# Altium schematic sheet Center Console - Buttons Interface.SchDoc("Center Console - Buttons Interface")
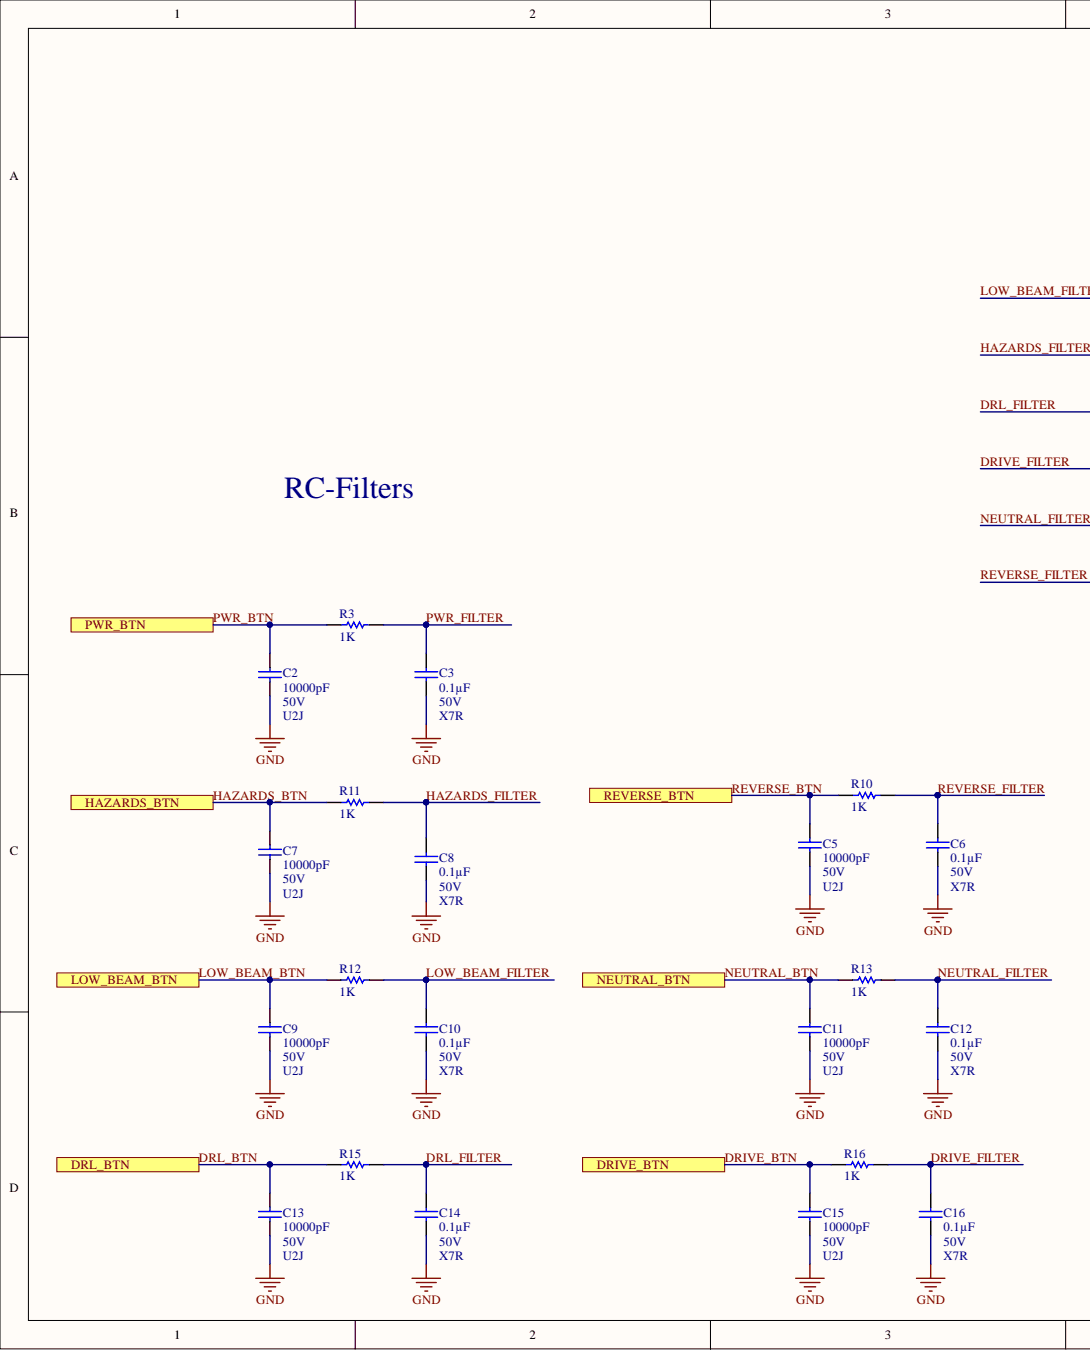
[diagram p0: Center Console - Buttons Interface.SchDoc, left]
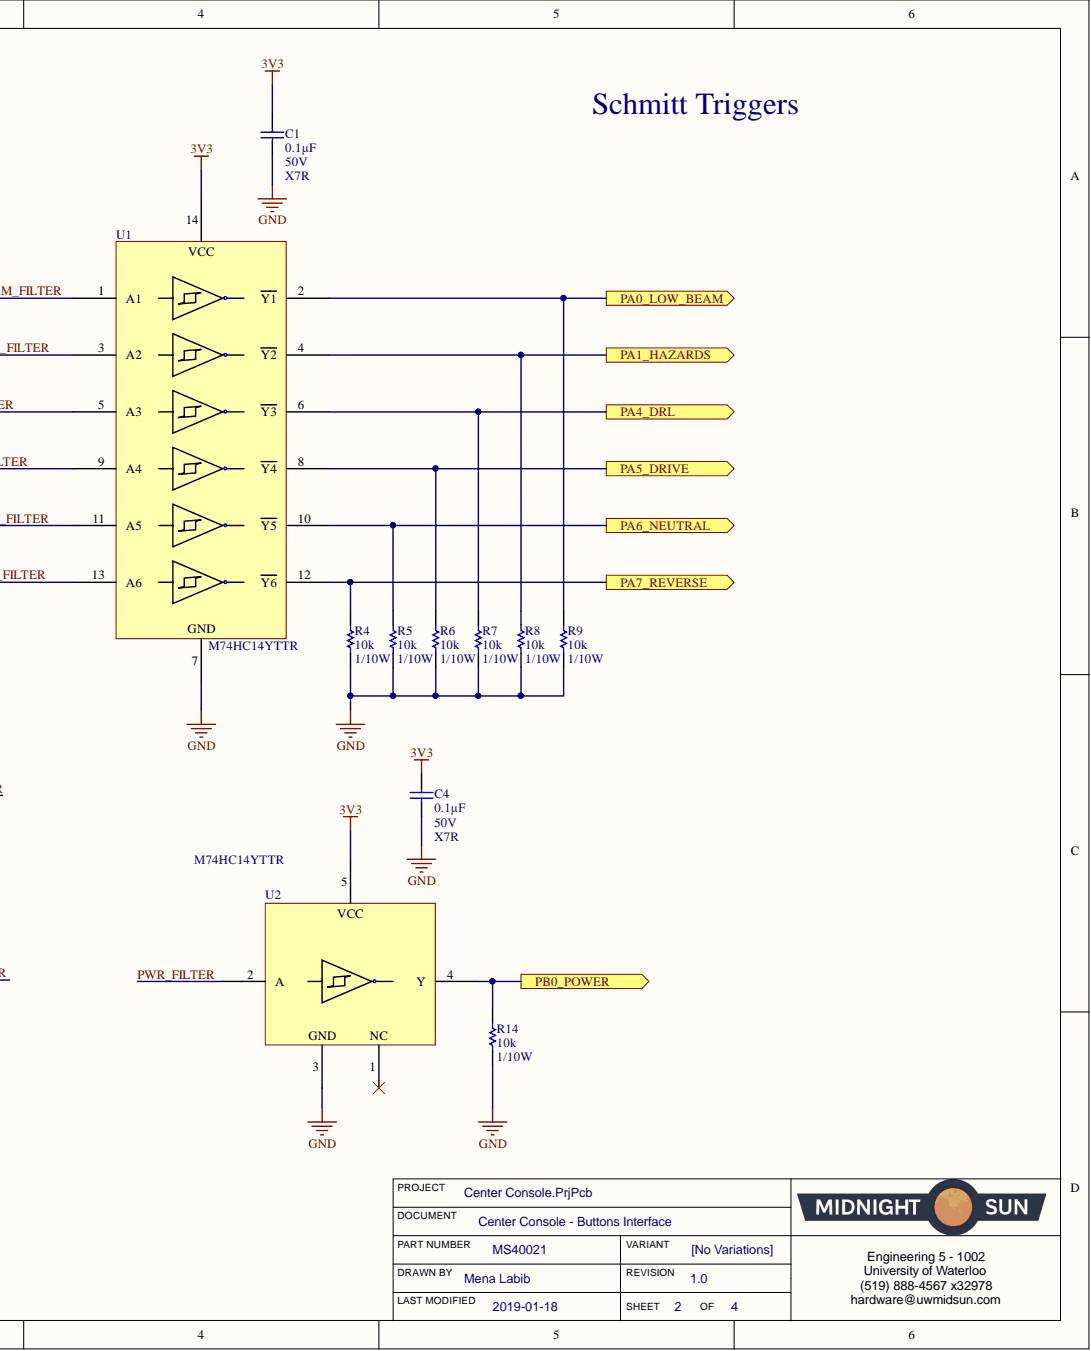
[diagram p1: Center Console - Buttons Interface.SchDoc, right]

## Parts
C1  Voltage: 50V  Temperature Coefficient: X7R  Capacitance: 0.1µF  [CAP, 0603]  (hidden comment: CAP CER 0.1UF 50V 10% X7R 0603)
C2  Voltage: 50V  Temperature Coefficient: U2J  Capacitance: 10000pF  [CAP, 0603]  (hidden comment: CAP CER 10nF 50V 5% X7R 0603)
C3  Voltage: 50V  Temperature Coefficient: X7R  Capacitance: 0.1µF  [CAP, 0603]  (hidden comment: CAP CER 0.1UF 50V 10% X7R 0603)
C4  Voltage: 50V  Temperature Coefficient: X7R  Capacitance: 0.1µF  [CAP, 0603]  (hidden comment: CAP CER 0.1UF 50V 10% X7R 0603)
C5  Voltage: 50V  Temperature Coefficient: U2J  Capacitance: 10000pF  [CAP, 0603]  (hidden comment: CAP CER 10nF 50V 5% X7R 0603)
C6  Voltage: 50V  Temperature Coefficient: X7R  Capacitance: 0.1µF  [CAP, 0603]  (hidden comment: CAP CER 0.1UF 50V 10% X7R 0603)
C7  Voltage: 50V  Temperature Coefficient: U2J  Capacitance: 10000pF  [CAP, 0603]  (hidden comment: CAP CER 10nF 50V 5% X7R 0603)
C8  Voltage: 50V  Temperature Coefficient: X7R  Capacitance: 0.1µF  [CAP, 0603]  (hidden comment: CAP CER 0.1UF 50V 10% X7R 0603)
C9  Voltage: 50V  Temperature Coefficient: U2J  Capacitance: 10000pF  [CAP, 0603]  (hidden comment: CAP CER 10nF 50V 5% X7R 0603)
C10  Voltage: 50V  Temperature Coefficient: X7R  Capacitance: 0.1µF  [CAP, 0603]  (hidden comment: CAP CER 0.1UF 50V 10% X7R 0603)
C11  Voltage: 50V  Temperature Coefficient: U2J  Capacitance: 10000pF  [CAP, 0603]  (hidden comment: CAP CER 10nF 50V 5% X7R 0603)
C12  Voltage: 50V  Temperature Coefficient: X7R  Capacitance: 0.1µF  [CAP, 0603]  (hidden comment: CAP CER 0.1UF 50V 10% X7R 0603)
C13  Voltage: 50V  Temperature Coefficient: U2J  Capacitance: 10000pF  [CAP, 0603]  (hidden comment: CAP CER 10nF 50V 5% X7R 0603)
C14  Voltage: 50V  Temperature Coefficient: X7R  Capacitance: 0.1µF  [CAP, 0603]  (hidden comment: CAP CER 0.1UF 50V 10% X7R 0603)
C15  Voltage: 50V  Temperature Coefficient: U2J  Capacitance: 10000pF  [CAP, 0603]  (hidden comment: CAP CER 10nF 50V 5% X7R 0603)
C16  Voltage: 50V  Temperature Coefficient: X7R  Capacitance: 0.1µF  [CAP, 0603]  (hidden comment: CAP CER 0.1UF 50V 10% X7R 0603)
R3  1K  [RES, 0603]
R4  Resistance: 10k  Power: 1/10W  [RES, 0603]  (hidden comment: RES 10K OHM 1% 1/10W 0603)
R5  Resistance: 10k  Power: 1/10W  [RES, 0603]  (hidden comment: RES 10K OHM 1% 1/10W 0603)
R6  Resistance: 10k  Power: 1/10W  [RES, 0603]  (hidden comment: RES 10K OHM 1% 1/10W 0603)
R7  Resistance: 10k  Power: 1/10W  [RES, 0603]  (hidden comment: RES 10K OHM 1% 1/10W 0603)
R8  Resistance: 10k  Power: 1/10W  [RES, 0603]  (hidden comment: RES 10K OHM 1% 1/10W 0603)
R9  Resistance: 10k  Power: 1/10W  [RES, 0603]  (hidden comment: RES 10K OHM 1% 1/10W 0603)
R10  1K  [RES, 0603]
R11  1K  [RES, 0603]
R12  1K  [RES, 0603]
R13  1K  [RES, 0603]
R14  Resistance: 10k  Power: 1/10W  [RES, 0603]  (hidden comment: RES 10K OHM 1% 1/10W 0603)
R15  1K  [RES, 0603]
R16  1K  [RES, 0603]
U1  Manufacturer Part Number: M74HC14YTTR  [IC INVERTER SCHMITT 6CH 14TSSOP]  (hidden comment: IC INVERTER SCHMITT 6CH 14TSSOP)
U2  Manufacturer Part Number: M74HC14YTTR  [IC INVERTER SCHMITT 1CH SC70-5]  (hidden comment: IC INVERTER SCHMITT 1CH SC70-5)

## Ports
DRIVE_BTN, DRL_BTN, HAZARDS_BTN, LOW_BEAM_BTN, NEUTRAL_BTN, PA0_LOW_BEAM, PA1_HAZARDS, PA4_DRL, PA5_DRIVE, PA6_NEUTRAL, PA7_REVERSE, PB0_POWER, PWR_BTN, REVERSE_BTN

## Nets
3V3: C1.1 C4.1 U1.14 U2.5
GND: C1.2 C2.2 C3.2 C4.2 C5.2 C6.2 C7.2 C8.2 C9.2 C10.2 C11.2 C12.2 C13.2 C14.2 C15.2 C16.2 R4.2 R5.2 R6.2 R7.2 R8.2 R9.2 R14.2 U1.7 U2.3
DRIVE_BTN: C15.1 R16.1 ; port DRIVE_BTN
DRIVE_FILTER_2: C16.1 R16.2 U1.9(A4)  [also labelled DRIVE_FILTER]
DRL_BTN: C13.1 R15.1 ; port DRL_BTN
DRL_FILTER_2: C14.1 R15.2 U1.5(A3)  [also labelled DRL_FILTER]
HAZARDS_BTN: C7.1 R11.1 ; port HAZARDS_BTN
HAZARDS_FILTER_2: C8.1 R11.2 U1.3(A2)  [also labelled HAZARDS_FILTER]
LOW_BEAM_BTN: C9.1 R12.1 ; port LOW_BEAM_BTN
LOW_BEAM_FILTER_2: C10.1 R12.2 U1.1(A1)  [also labelled LOW_BEAM_FILTER]
NEUTRAL_BTN: C11.1 R13.1 ; port NEUTRAL_BTN
NEUTRAL_FILTER_2: C12.1 R13.2 U1.11(A5)  [also labelled NEUTRAL_FILTER]
PA0_LOW_BEAM: R9.1 U1.2(Y1) ; port PA0_LOW_BEAM
PA1_HAZARDS: R8.1 U1.4(Y2) ; port PA1_HAZARDS
PA4_DRL: R7.1 U1.6(Y3) ; port PA4_DRL
PA5_DRIVE: R6.1 U1.8(Y4) ; port PA5_DRIVE
PA6_NEUTRAL: R5.1 U1.10(Y5) ; port PA6_NEUTRAL
PA7_REVERSE: R4.1 U1.12(Y6) ; port PA7_REVERSE
PB0_POWER: R14.1 U2.4(Y) ; port PB0_POWER
PWR_BTN: C2.1 R3.1 ; port PWR_BTN
PWR_FILTER_2: C3.1 R3.2 U2.2(A)  [also labelled PWR_FILTER]
REVERSE_BTN: C5.1 R10.1 ; port REVERSE_BTN
REVERSE_FILTER_2: C6.1 R10.2 U1.13(A6)  [also labelled REVERSE_FILTER]
NetU2_1: U2.1(NC)

## Library data of the parts
C1 C3 C4 C6 C8 C10 C12 C14 C16 = CAP CER 0.1UF 50V 10% X7R 0603: 0.10µF ±10% 50V Ceramic Capacitor X7R 0603 (1608 Metric); Manufacturer Part Number 1: 06035C104KAT2A; Manufacturer 1: AVX Corporation; Tolerance: ±10%; Thickness (Max): 0.035" (0.90mm); Supplier Part Number 1: 478-5052-1-ND; Supplier 1: Digi-Key; Size / Dimension: 0.063" L x 0.032" W (1.60mm x 0.81mm); Ratings: -; Part Status: Active; Packaging: Cut Tape (CT); Package / Case: 0603 (1608 Metric); Operating Temperature: -55°C ~ 125°C; Mounting Type: Surface Mount, MLCC; Lead Style: -; Lead Spacing: -; Height - Seated (Max): -; ComponentLink1URL: http://datasheets.avx.com/X7RDielectric.pdf; ComponentLink1Description: http://datasheets.avx.com/X7RDielectric.pdf; Component Kind: Standard; Library Name: Schematic Diagrams.SchLib
C2 C5 C7 C9 C11 C13 C15 = CAP CER 10nF 50V 5% X7R 0603: 10000pF ±5% 50V Ceramic Capacitor U2J 0603 (1608 Metric); Manufacturer Part Number 1: C0603C103J5JAC7867; Manufacturer 1: KEMET; Tolerance: ±5%; Thickness (Max): 0.034" (0.87mm); Supplier Part Number 1: 399-13384-1-ND; Supplier 1: Digi-Key; Size / Dimension: 0.063" L x 0.032" W (1.60mm x 0.80mm); Ratings: -; Part Status: Active; Packaging: Cut Tape (CT); Package / Case: 0603 (1608 Metric); Operating Temperature: -55°C ~ 125°C; Mounting Type: Surface Mount, MLCC; Lead Style: -; Lead Spacing: -; Height - Seated (Max): -; Failure Rate: -; ComponentLink1URL: http://www.kemet.com/docfinder?Partnumber=C0603C103J5JAC7867; ComponentLink1Description: http://www.kemet.com/docfinder?Partnumber=C0603C103J5JAC7867; Component Kind: Standard
R3 R10-R13 R15 R16 = RES 1K OHM 5% 1/10W 0603: 1k Ohm ±5% 0.1W, 1/10W Chip Resistor 0603 (1608 Metric); Manufacturer Part Number: RC0603JR-071KL; Manufacturer: Yageo; Temperature Coefficient: ±100ppm/°C; Tolerance: ±5%; Supplier Part Number 1: 311-1.0KGRCT-ND; Supplier Device Package: 0603; Supplier 1: Digi-Key; Stock 1: 4415240; Size / Dimension: 0.063" L x 0.031" W (1.60mm x 0.80mm); RoHS: RoHS Compliant; Resistance (Ohms): 1k; Pricing 1: 1=0.15, 10=0.017, 100=0.0071, 1000=0.0032, 2500=0.00278 (CAD); Power (Watts): 0.1W, 1/10W; Part Status: Active; Packaging: Cut Tape (CT); Package / Case: 0603 (1608 Metric); Operating Temperature: -55°C ~ 155°C; Height - Seated (Max): 0.022" (0.55mm); Features: Moisture Resistant; Failure Rate: -; Composition: Thick Film; ComponentLink1URL: http://www.yageo.com/documents/recent/PYu-RC_Group_51_RoHS_L_7.pdf; ComponentLink1Description: http://www.yageo.com/documents/recent/PYu-RC_Group_51_RoHS_L_7.pdf; Component Kind: Standard; Category: Resistors; Library Name: Schematic Diagrams.SchLib
R4-R9 R14 = RES 10K OHM 1% 1/10W 0603: RES SMD 10K OHM 1% 1/10W 0603; Manufacturer Part Number 1: RC0603FR-0710KL; Manufacturer 1: Yageo; Temperature Coefficient: ±100ppm/°C; Tolerance: ±1%; Supplier Part Number 1: 311-10.0KHRCT-ND; Supplier Device Package: 0603; Supplier 1: Digi-Key; Size / Dimension: 0.063" L x 0.031" W (1.60mm x 0.80mm); Part Status: Active; Packaging: Cut Tape (CT); Package / Case: 0603 (1608 Metric); Operating Temperature: -55°C ~ 155°C; Height - Seated (Max): 0.022" (0.55mm); Failure Rate: -; Composition: Thick Film; ComponentLink1URL: http://www.yageo.com/documents/recent/PYu-RC_Group_51_RoHS_L_7.pdf; ComponentLink1Description: http://www.yageo.com/documents/recent/PYu-RC_Group_51_RoHS_L_7.pdf; Component Kind: Standard
U1 = IC INVERTER SCHMITT 6CH 14TSSOP: Inverter IC 6 Channel Schmitt Trigger 14-TSSOP; Manufacturer: STMicroelectronics; Turn-On Delay Time: 25ns; Quiescent Current: 1uA; Propagation Delay: 21ns; Number of Inputs: 6; Min Supply Voltage: 2V; Max Supply Voltage: 6V; Low Level Output Current: 5.2mA; Weight: 0.004949oz; Supplier Part Number 1: 497-14387-1-ND; Supplier 1: Digi-Key; Stock 1: 20035; RoHS: Yes; Pricing 1: 1 = 0.44000, 10 = 0.37500, 100 = 0.27970, 500 = 0.21980, 1000 = 0.16985 (USD); Packaging: Tape and Reel; Package Quantity: 2500; Number of Pins: 14; Number of Circuits: 6; Mount: Surface Mount; Min Operating Temperature: -40°C; Max Operating Temperature: 125°C; Logic Function: Inverter; Lead Free: Lead Free; High Level Output Current: 5.2mA; ComponentLink3URL: http://www.st.com/st-web-ui/static/active/en/resource/technical/document/datasheet/CD00000263.pdf; ComponentLink3Description: http://www.st.com/st-web-ui/static/active/en/resource/technical/document/datasheet/CD00000263.pdf; ComponentLink2URL: http://www.st.com/content/ccc/resource/technical/document/datasheet/19/a3/52/42/dd/ab/4b/46/CD00000263.pdf/files/CD00000263.pdf/jcr:content/translations/en.CD00000263.pdf; ComponentLink2Description: http://www.st.com/content/ccc/resource/technical/document/datasheet/19/a3/52/42/dd/ab/4b/46/CD00000263.pdf/files/CD00000263.pdf/jcr:content/translations/en.CD00000263.pdf; ComponentLink1URL: https://www.digikey.ca/product-detail/en/stmicroelectronics/M74HC14YTTR/497-14387-1-ND/4725844; ComponentLink1Description: Digikey; Component Kind: Standard; Case/Package: TSSOP; Library Name: Schematic Diagrams.SchLib
U2 = IC INVERTER SCHMITT 1CH SC70-5: Inverter IC 1 Channel Schmitt Trigger SC-70-5; Manufacturer: STMicroelectronics; Turn-On Delay Time: 25ns; Quiescent Current: 1uA; Propagation Delay: 21ns; Number of Inputs: 6; Number of Bits: 1; Min Supply Voltage: 2V; Max Supply Voltage: 6V; Low Level Output Current: 5.2mA; Weight: 0.004949oz; Supplier Part Number 1: 296-47215-1-ND; Supplier 1: Digi-Key; Stock 1: 11031; RoHS: Yes; Pricing 1: 1 = 0.36000, 10 = 0.29000, 100 = 0.19780, 500 = 0.14832, 1000 = 0.11124 (USD); Packaging: Tape and Reel; Package Quantity: 2500; Number of Pins: 14; Number of Circuits: 6; Mount: Surface Mount; Min Operating Temperature: -40°C; Max Operating Temperature: 125°C; Logic Function: Inverter; Lead Free: Lead Free; High Level Output Current: 5.2mA; Component Kind: Standard; Case/Package: TSSOP; Library Name: Schematic Diagrams.SchLib
